# Altium schematic sheet MCU.SchDoc(MCU)
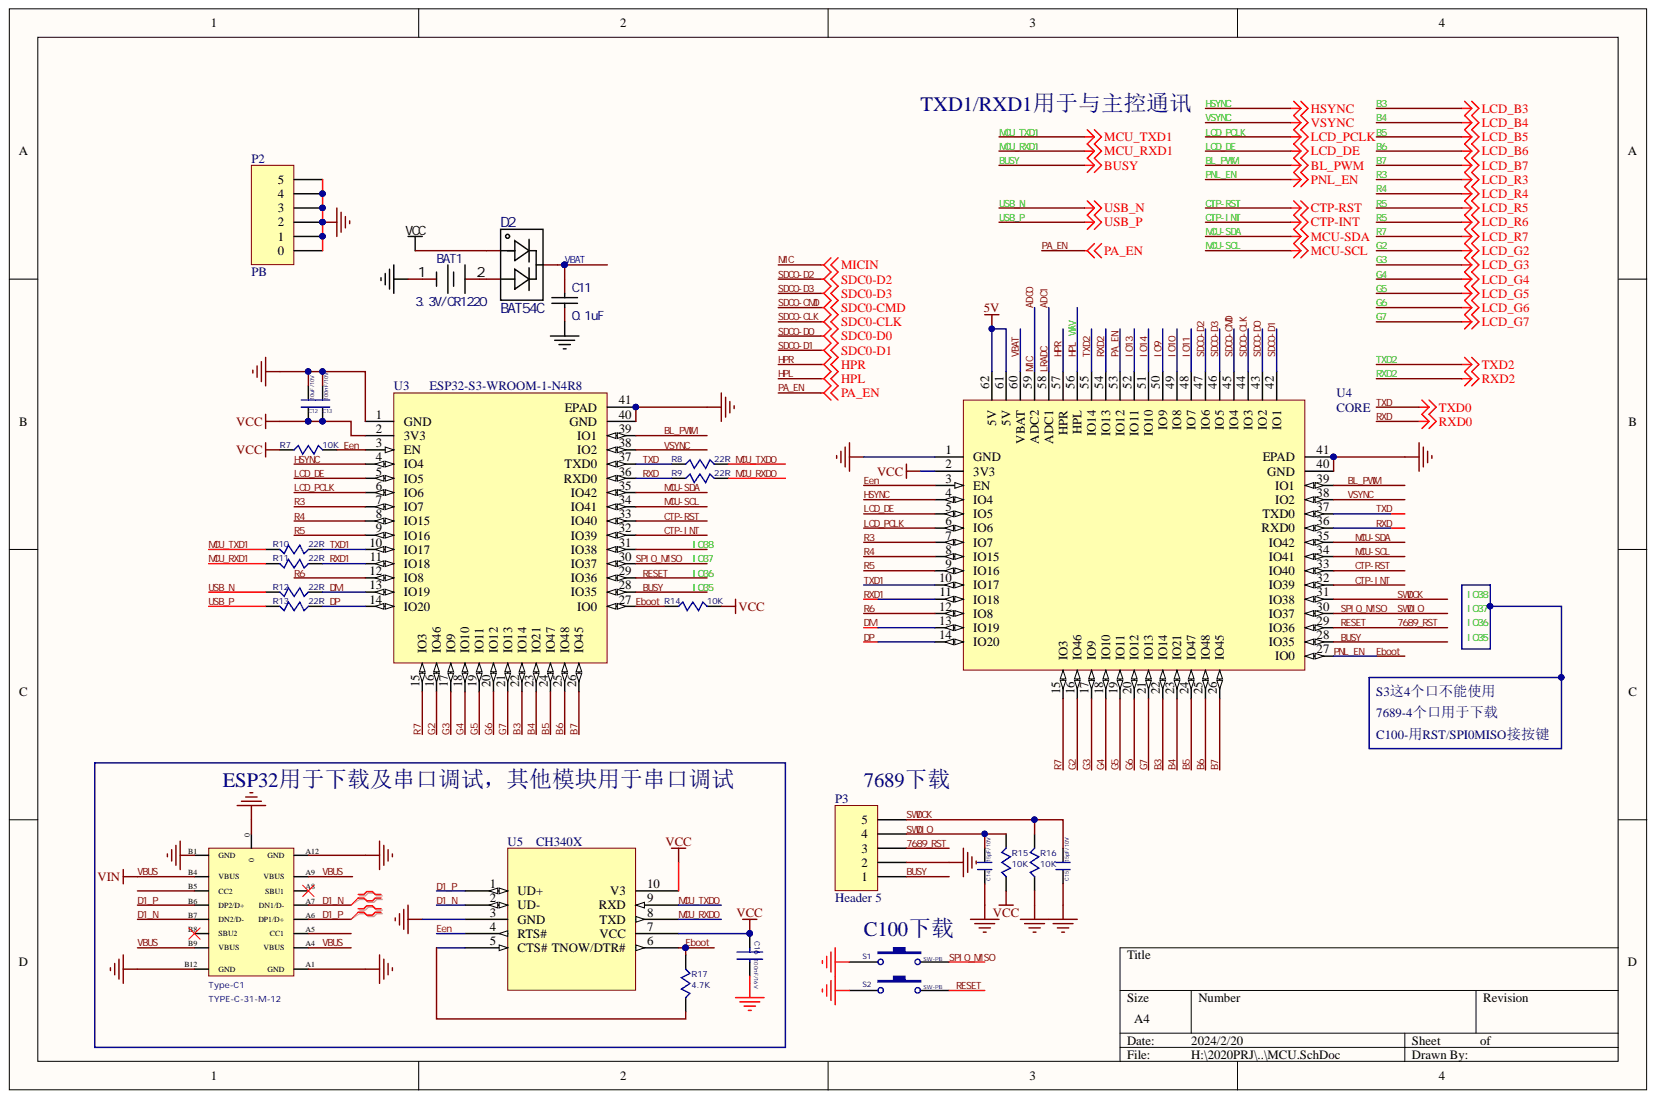
[diagram p0: MCU.SchDoc]

## Parts
BAT1  3.3V/CR1220  [BAT-SMD]
C11  0.1uF  [C0402]
C12  10uF/10V  [c0603]
C13  100nF/10V  [C0402]
C14  15pF/10V  [C0402]
C15  15pF/10V  [C0402]
C16  100nF/16V  [C0402]
D2  BAT54C  [SOT-23]
P2  PB  [PB]
P3  Header 5  [HDR1X5]
R7  10K  [R0402]
R8  22R  [R0402]
R9  22R  [R0402]
R10  22R  [R0402]
R11  22R  [R0402]
R12  22R  [R0402]
R13  22R  [R0402]
R14  10K  [R0402]
R15  10K  [R0402]
R16  10K  [R0402]
R17  4.7K  [R0402]
S1  SW-PB  [Micro-Key]
S2  SW-PB  [Micro-Key]
Type-C1  TYPE-C-31-M-12  [TYPE-C-12P]
U3  ESP32-S3-WROOM-1-N4R8  [ESFS-ESP32-S3-WROOM-1_V]
U4  CORE  [CORE]
U5  CH340X  [MSOP10]

## Nets
5V: U4.61(5V) U4.62(5V)
GND: BAT1.1 C11.2 C12.2 C13.1 C14.1 C15.1 C16.1 P2.0 P2.1 P2.2 P2.3 P2.4 P2.5 P3.2 R16.1 S1.1 S2.1 Type-C1.0 Type-C1.A1(GND) Type-C1.A12(GND) Type-C1.B1(GND) Type-C1.B12(GND) U3.1(GND) U3.40(GND) U3.41(EPAD) U4.1(GND) U4.40(GND) U4.41(EPAD) U5.3(GND)
VCC: C12.1 C13.2 C16.2 D2.1 R7.1 R14.2 R15.1 U3.2(3V3) U4.2(3V3) U5.7(VCC) U5.10(V3)
7689_RST: P3.3 S2.2 U3.29(IO36) U4.29(IO36)
ADC0: U4.59(ADC2)
ADC1: U4.58(ADC1)
B3: U3.22(IO14) U4.22(IO14)
B4: U3.23(IO21) U4.23(IO21)
B5: U3.24(IO47) U4.24(IO47)
B6: U3.25(IO48) U4.25(IO48)
B7: U3.26(IO45) U4.26(IO45)
BL_PWM: U3.39(IO1) U4.39(IO1)
BUSY: P3.1 U3.28(IO35) U4.28(IO35)
CTP-INT: U3.32(IO39) U4.32(IO39)
CTP-RST: U3.33(IO40) U4.33(IO40)
D1_N: Type-C1.A7(DN1/D-) Type-C1.B7(DN2/D-) U5.2(UD-)
D1_P: Type-C1.A6(DP1/D+) Type-C1.B6(DP2/D+) U5.1(UD+)
DM: R12.1 U3.13(IO19) U4.13(IO19)
DP: R13.1 U3.14(IO20) U4.14(IO20)
EBOOT: R14.1 R17.1 U3.27(IO0) U4.27(IO0) U5.6(TNOW/DTR#)
EEN: R7.2 U3.3(EN) U4.3(EN) U5.4(RTS#)
G2: U3.16(IO46) U4.16(IO46)
G3: U3.17(IO9) U4.17(IO9)
G4: U3.18(IO10) U4.18(IO10)
G5: U3.19(IO11) U4.19(IO11)
G6: U3.20(IO12) U4.20(IO12)
G7: U3.21(IO13) U4.21(IO13)
HPL: U4.56(HPL)
HPR: U4.57(HPR)
HSYNC: U3.4(IO4) U4.4(IO4)
IO9: U4.50(IO9)
IO10: U4.49(IO8)
IO11: U4.48(IO7)
IO13: U4.52(IO11)
IO14: U4.51(IO10)
IO37: C14.2 P3.4 R15.2 S1.2 U3.30(IO37) U4.30(IO37)
IO38: U3.31(IO38)
LCD_DE: U3.5(IO5) U4.5(IO5)
LCD_PCLK: U3.6(IO6) U4.6(IO6)
MCU-SCL: U3.34(IO41) U4.34(IO41)
MCU-SDA: U3.35(IO42) U4.35(IO42)
MCU_RXD0: R9.2 U5.8(TXD)
MCU_RXD1: R11.2
MCU_TXD0: R8.2 U5.9(RXD)
MCU_TXD1: R10.2
PA_EN: U4.53(IO12)
R3: U3.7(IO7) U4.7(IO7)
R4: U3.8(IO15) U4.8(IO15)
R5: U3.9(IO16) U4.9(IO16)
R6: U3.12(IO8) U4.12(IO8)
R7: U3.15(IO3) U4.15(IO3)
RXD: R9.1 U3.36(RXD0) U4.36(RXD0)
RXD1: R11.1 U3.11(IO18) U4.11(IO18)
RXD2: U4.54(IO13)
SDC0-CLK: U4.44(IO3)
SDC0-CMD: U4.45(IO4)
SDC0-D0: U4.43(IO2)
SDC0-D1: U4.42(IO1)
SDC0-D2: U4.47(IO6)
SDC0-D3: U4.46(IO5)
SWDCK: C15.2 P3.5 R16.2 U4.31(IO38)
TXD: R8.1 U3.37(TXD0) U4.37(TXD0)
TXD1: R10.1 U3.10(IO17) U4.10(IO17)
TXD2: U4.55(IO14)
USB_N: R12.2
USB_P: R13.2
VBAT: C11.1 D2.3 U4.60(VBAT)
VBUS: Type-C1.A4(VBUS) Type-C1.A9(VBUS) Type-C1.B4(VBUS) Type-C1.B9(VBUS)
VSYNC: U3.38(IO2) U4.38(IO2)
NetBAT1_2: BAT1.2 D2.2
NetR17_2: R17.2 U5.5(CTS#)
N00096:
N00097:

Not connected: Type-C1 A5(CC1) A8(SBU1) B5(CC2) B8(SBU2)

## Library data of the parts
BAT1 = BAT: Battery; Add into BOM: yes; Origin Footprint 2: CN_SMD_1.54_2_4_180; Origin Footprint 3: CON_1.5_SMD_2PIN_5; Origin Footprint 4: CON_DIP_2_1.5_90; Origin Footprint 5: CON_DIP_2_2.0_90; Component Kind: Standard; Convert to PCB: yes; Device: BAT; Name: 3.3V/CR1220; Origin Footprint 1: Bat-SMD; Symbol: BAT
C11 = CAP_C0603: Capacitor; Add into BOM: yes; Origin Footprint 1: C0603; Symbol: CAP; Component Kind: Standard; Convert to PCB: yes; Device: CAP_C0603; Name: 0.1uF; Origin Footprint 2: C0603
C12-C15 = CAPX: Component Kind: Standard; Library Name: JRP7813.SCHLIB
C16 = CAP NP_Dup2: Component Kind: Standard
D2 = BAT54C_C727125: 二极管配置:1对共阴极;直流反向耐压(Vr):30V;平均整流电流(Io):200mA;正向压降(Vf):1V@100mA;反向电流(Ir):2uA@25V;; Manufacturer: TWGMC(台湾迪嘉); Add into BOM: yes; Average Rectified Current (Io): 200mA; Diode Configuration: 1对共阴极; Reverse Voltage (Vr): 30V; Component Kind: Standard; Convert to PCB: yes; Datasheet: https://atta.szlcsc.com/upload/public/pdf/source/20200827/C727125_FA9D830E6485A4AEFAD08726CE8D43AC.pdf; Device: BAT54C_C727125; Forward Voltage (Vf@If): 1V@100mA; JLCPCB Part Class: 扩展库; LCSC Part Name: 30V 200mA 1V@100mA; Manufacturer Part: BAT54C; Name: BAT54C; PCB3D: SOT-23-3_L2.9-W1.4-P1.91-LS2.6-BR; Reverse Leakage Current (Ir): 2uA@25V; Supplier: LCSC; Supplier Footprint: SOT-23; Supplier Part: C727125; Symbol: BAT54C_C727125
P3 = Header 5: Header, 5-Pin; Component Kind: Standard; LatestRevisionDate: 17-Jul-2002; LatestRevisionNote: Re-released for DXP Platform.; Library Name: Miscellaneous Connectors.IntLib; Signal Integrity: Connector; Publisher: Altium Limited
R7-R9 R14-R17 = RS-05L8R2JT: 贴片电阻; 品牌: FH(风华); 封装规格: 0805; Component Kind: Standard; 厂家型号: RS-05L8R2JT
R10-R13 = RS-05K1003FT: 贴片电阻; 品牌: FH(风华); 封装规格: 0805; Component Kind: Standard; 厂家型号: RS-05K1003FT
S1 S2 = SW-PB: Switch; Component Kind: Standard; LatestRevisionDate: 17-Jul-2002; LatestRevisionNote: Re-released for DXP Platform.; Library Name: Miscellaneous Devices.IntLib; PackageDescription: Switch; 2 Leads; PackageReference: SPST-2; Published: 24-Mar-1999; Publisher: Altium Limited
Type-C1 = TYPE-C-31-M-12: 12pin type-c; Component Kind: Standard; Library Name: HLib.IntLib
U3 = ESP32-S3-WROOM-1-N4R8: 2.4 GHz Wi-Fi and Bluetooth 5 Module, ESP32-S3R8, 4 MB Flash, 8 MB PSRAM, PCB Antenna, -40 to 65 degC, 40-Pin SMD, RoHS, Tape and Reel; Manufacturer: Espressif Systems; Bluetooth: Bluetooth LE v5.0; Flash: 4 MB; Frequery: 240 MHz; GPIO: 36; PSRAM: 8 MB; ROM: 384 KB; SRAM: 512 KB; Wi-Fi: IEEE 802.11 b/g/n; 2.4 GHz; HT20/40; up to 150 Mbps; Component Kind: Standard; ComponentLink1Description: Manufacturer URL; ComponentLink1URL: https://www.espressif.com/; ComponentLink2Description: Datasheet Link; ComponentLink2URL: https://www.espressif.com/sites/default/files/documentation/esp32-s3-wroom-1_wroom-1u_datasheet_en.pdf; Datasheet Version: Rev. 1.0, 04/2022; Library Name: Espressif Systems SoCs And Modules.SchLib; Mounting Technology: Surface Mount; Operating Temperature: –40 °C ~ +65 °C; Package Description: 40-Pin Surface Mount Module, 18 x 25.5 mm Body, 1.27 mm Pitch, 3.1 mm Height; Package Reference: ESP32-S3-WROOM-1; Part Number: ESP32-S3-WROOM-1-N4R8; Type: Module; Publisher: Bolgen Studio
U4 = CORE: Manufacturer: Espressif Systems; Part Number: ESP32-S3-WROOM-1-N4R8; Bluetooth: Bluetooth LE v5.0; Flash: 4 MB; Frequery: 240 MHz; GPIO: 36; PSRAM: 8 MB; ROM: 384 KB; SRAM: 512 KB; Wi-Fi: IEEE 802.11 b/g/n; 2.4 GHz; HT20/40; up to 150 Mbps; Component Kind: Standard; ComponentLink1Description: Manufacturer URL; ComponentLink1URL: https://www.espressif.com/; ComponentLink2Description: Datasheet Link; ComponentLink2URL: https://www.espressif.com/sites/default/files/documentation/esp32-s3-wroom-1_wroom-1u_datasheet_en.pdf; Datasheet Version: Rev. 1.0, 04/2022; Description: CORE; Library Name: PCB-Core100S.SCHLIB; Mounting Technology: Surface Mount; Operating Temperature: –40 °C ~ +65 °C; Package Description: 40-Pin Surface Mount Module, 18 x 25.5 mm Body, 1.27 mm Pitch, 3.1 mm Height; Package Reference: ESP32-S3-WROOM-1; Type: Module; Publisher: Bolgen Studio
U5 = CH340X: USB to TTL Serial / UART; Component Kind: Standard; Library Name: CHXXX.schlib
P2 = PB: Component Kind: Standard; Library Name: EU1013.SCHLIB
